# Altium schematic sheet sch1.SchDoc
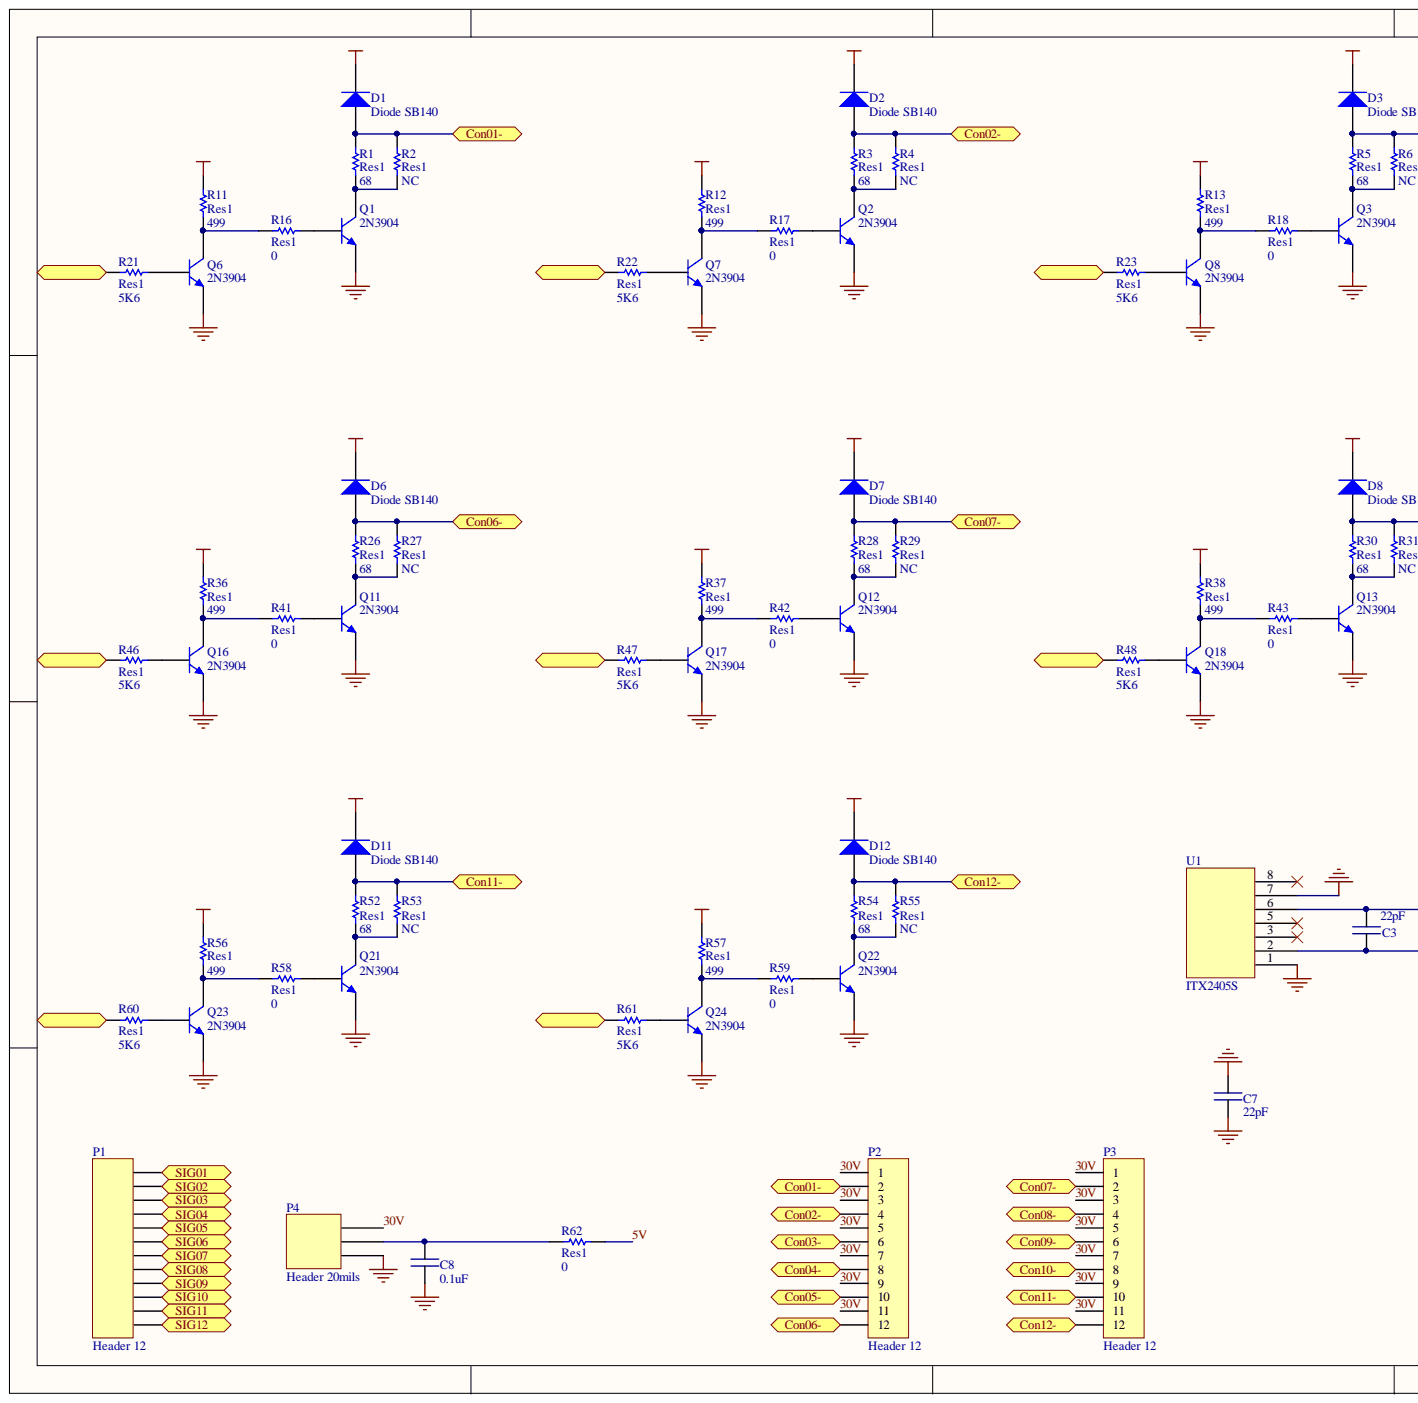
[diagram p0: sch1.SchDoc, left]
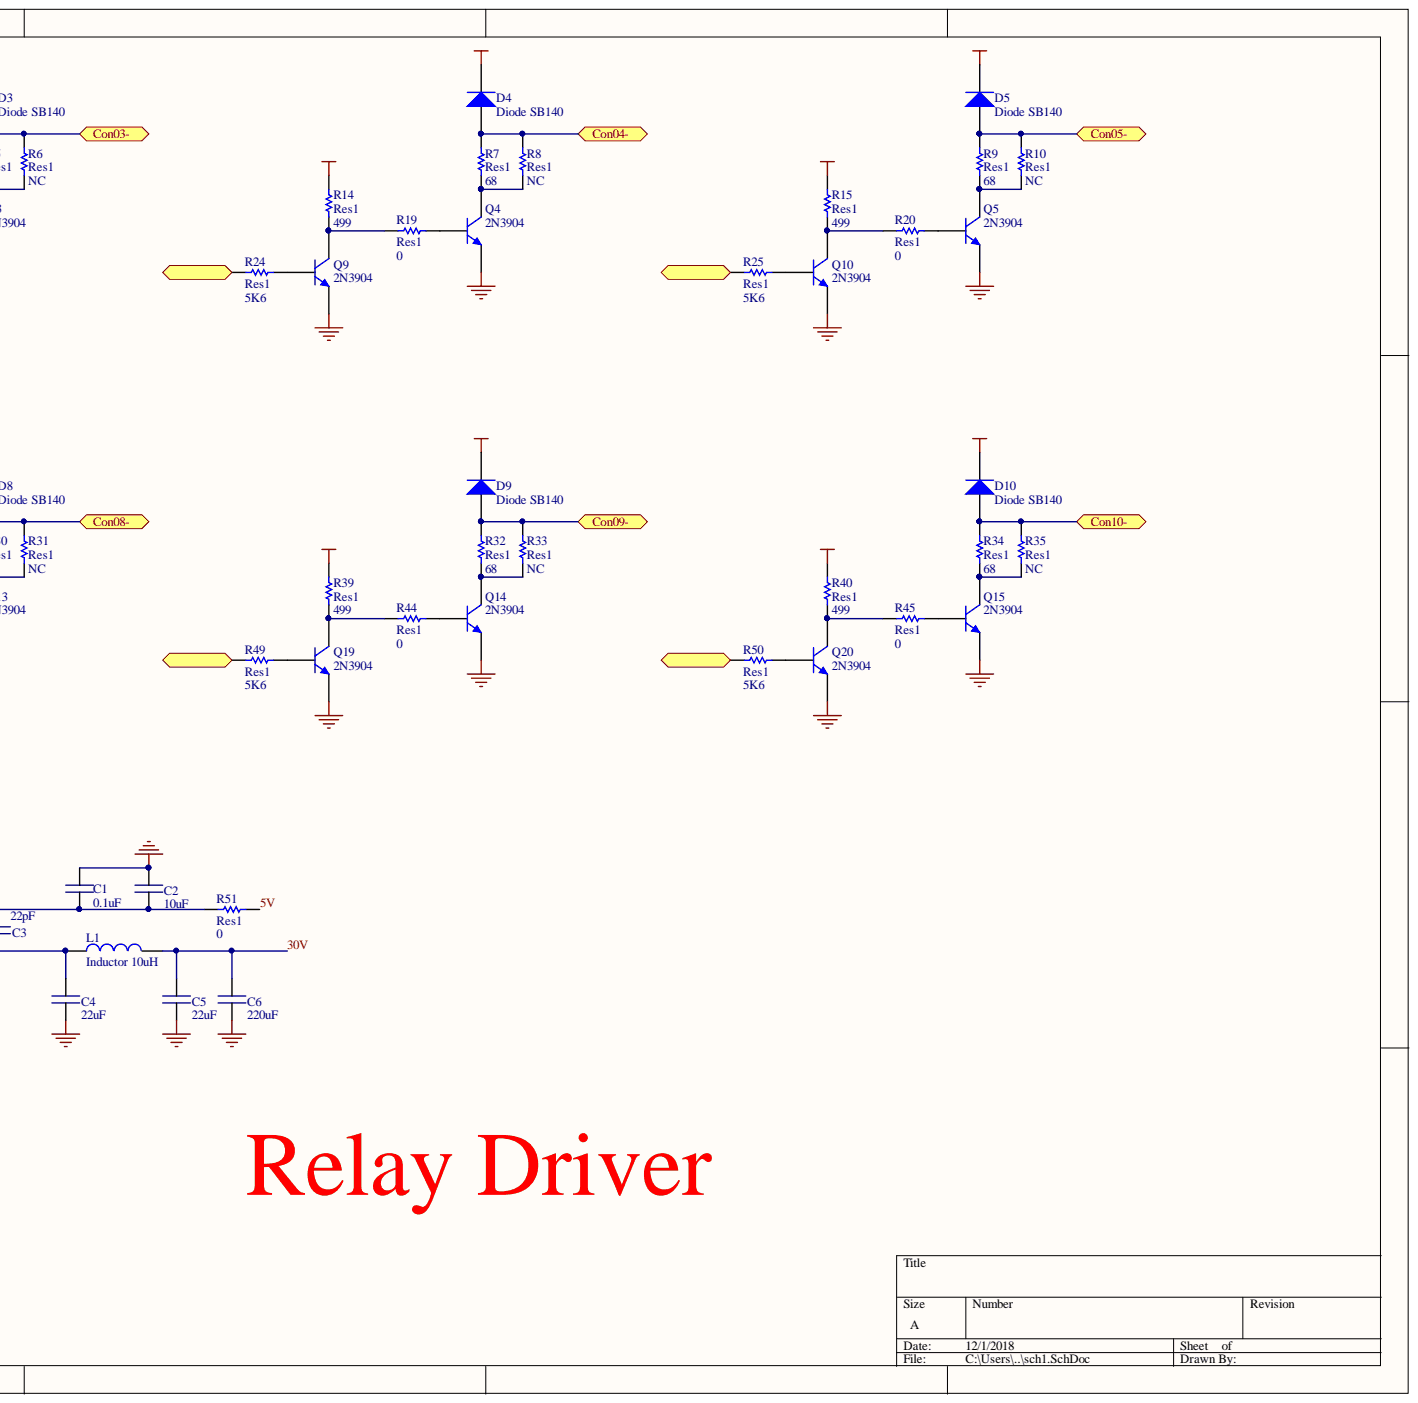
[diagram p1: sch1.SchDoc, right]

## Parts
C1  value 0.1uF  [0805 (2012 metric)]  (hidden comment: Cap 0603)
C2  value 10uF  [0805 (2012 metric)]  (hidden comment: Cap 0603)
C3  value 22pF  [0603 (1608 Metric)]  (hidden comment: Cap 0603)
C4  value 22uF  [0805 (2012 metric)]  (hidden comment: Cap 0603)
C5  value 22uF  [0805 (2012 metric)]  (hidden comment: Cap 0603)
C6  value 220uF  [0805 (2012 metric)]  (hidden comment: Cap 0603)
C7  value 22pF  [0603 (1608 Metric)]  (hidden comment: Cap 0603)
C8  value 0.1uF  [0603 (1608 Metric)]  (hidden comment: Cap 0603)
D1  Diode SB140  [DO-41]
D2  Diode SB140  [DO-41]
D3  Diode SB140  [DO-41]
D4  Diode SB140  [DO-41]
D5  Diode SB140  [DO-41]
D6  Diode SB140  [DO-41]
D7  Diode SB140  [DO-41]
D8  Diode SB140  [DO-41]
D9  Diode SB140  [DO-41]
D10  Diode SB140  [DO-41]
D11  Diode SB140  [DO-41]
D12  Diode SB140  [DO-41]
L1  Inductor 10uH  [Inductor Surface Mount]
P1  Header 12  [HDR1X12]
P2  Header 12  [HDR1X12]
P3  Header 12  [HDR1X12]
P4  Header 20mils  [Header_20mils]
Q1  2N3904  [TO-92A]
Q2  2N3904  [TO-92A]
Q3  2N3904  [TO-92A]
Q4  2N3904  [TO-92A]
Q5  2N3904  [TO-92A]
Q6  2N3904  [TO-92A]
Q7  2N3904  [TO-92A]
Q8  2N3904  [TO-92A]
Q9  2N3904  [TO-92A]
Q10  2N3904  [TO-92A]
Q11  2N3904  [TO-92A]
Q12  2N3904  [TO-92A]
Q13  2N3904  [TO-92A]
Q14  2N3904  [TO-92A]
Q15  2N3904  [TO-92A]
Q16  2N3904  [TO-92A]
Q17  2N3904  [TO-92A]
Q18  2N3904  [TO-92A]
Q19  2N3904  [TO-92A]
Q20  2N3904  [TO-92A]
Q21  2N3904  [TO-92A]
Q22  2N3904  [TO-92A]
Q23  2N3904  [TO-92A]
Q24  2N3904  [TO-92A]
R1  Res1  value 68  [AXIAL-0.6]
R2  Res1  value NC  [AXIAL-0.6]
R3  Res1  value 68  [AXIAL-0.6]
R4  Res1  value NC  [AXIAL-0.6]
R5  Res1  value 68  [AXIAL-0.6]
R6  Res1  value NC  [AXIAL-0.6]
R7  Res1  value 68  [AXIAL-0.6]
R8  Res1  value NC  [AXIAL-0.6]
R9  Res1  value 68  [AXIAL-0.6]
R10  Res1  value NC  [AXIAL-0.6]
R11  Res1  value 499  [6-0805_N]
R12  Res1  value 499  [6-0805_N]
R13  Res1  value 499  [6-0805_N]
R14  Res1  value 499  [6-0805_N]
R15  Res1  value 499  [6-0805_N]
R16  Res1  value 0  [6-0805_N]
R17  Res1  value 0  [6-0805_N]
R18  Res1  value 0  [6-0805_N]
R19  Res1  value 0  [6-0805_N]
R20  Res1  value 0  [6-0805_N]
R21  Res1  value 5K6  [6-0805_N]
R22  Res1  value 5K6  [6-0805_N]
R23  Res1  value 5K6  [6-0805_N]
R24  Res1  value 5K6  [6-0805_N]
R25  Res1  value 5K6  [6-0805_N]
R26  Res1  value 68  [AXIAL-0.6]
R27  Res1  value NC  [AXIAL-0.6]
R28  Res1  value 68  [AXIAL-0.6]
R29  Res1  value NC  [AXIAL-0.6]
R30  Res1  value 68  [AXIAL-0.6]
R31  Res1  value NC  [AXIAL-0.6]
R32  Res1  value 68  [AXIAL-0.6]
R33  Res1  value NC  [AXIAL-0.6]
R34  Res1  value 68  [AXIAL-0.6]
R35  Res1  value NC  [AXIAL-0.6]
R36  Res1  value 499  [6-0805_N]
R37  Res1  value 499  [6-0805_N]
R38  Res1  value 499  [6-0805_N]
R39  Res1  value 499  [6-0805_N]
R40  Res1  value 499  [6-0805_N]
R41  Res1  value 0  [6-0805_N]
R42  Res1  value 0  [6-0805_N]
R43  Res1  value 0  [6-0805_N]
R44  Res1  value 0  [6-0805_N]
R45  Res1  value 0  [6-0805_N]
R46  Res1  value 5K6  [6-0805_N]
R47  Res1  value 5K6  [6-0805_N]
R48  Res1  value 5K6  [6-0805_N]
R49  Res1  value 5K6  [6-0805_N]
R50  Res1  value 5K6  [6-0805_N]
R51  Res1  value 0  [6-0805_N]
R52  Res1  value 68  [AXIAL-0.6]
R53  Res1  value NC  [AXIAL-0.6]
R54  Res1  value 68  [AXIAL-0.6]
R55  Res1  value NC  [AXIAL-0.6]
R56  Res1  value 499  [6-0805_N]
R57  Res1  value 499  [6-0805_N]
R58  Res1  value 0  [6-0805_N]
R59  Res1  value 0  [6-0805_N]
R60  Res1  value 5K6  [6-0805_N]
R61  Res1  value 5K6  [6-0805_N]
R62  Res1  value 0  [6-0805_N]
U1  ITX2405S  [ITX2405S]

## Ports
Con01-, Con02-, Con03-, Con04-, Con05-, Con06-, Con07-, Con08-, Con09-, Con10-, Con11-, Con12-, SIG01, SIG02, SIG03, SIG04, SIG05, SIG06, SIG07, SIG08, SIG09, SIG10, SIG11, SIG12

## Nets
GND: C1.2 C2.2 C4.1 C5.1 C6.1 C7.1 C7.2 C8.1 P4.3 Q1.1 Q2.1 Q3.1 Q4.1 Q5.1 Q6.1 Q7.1 Q8.1 Q9.1 Q10.1 Q11.1 Q12.1 Q13.1 Q14.1 Q15.1 Q16.1 Q17.1 Q18.1 Q19.1 Q20.1 Q21.1 Q22.1 Q23.1 Q24.1 U1.1 U1.7
5V: R11.2 R12.2 R13.2 R14.2 R15.2 R36.2 R37.2 R38.2 R39.2 R40.2 R51.2 R56.2 R57.2 R62.2
30V: C5.2 C6.2 D1.2 D2.2 D3.2 D4.2 D5.2 D6.2 D7.2 D8.2 D9.2 D10.2 D11.2 D12.2 L1.2 P2.1 P2.3 P2.5 P2.7 P2.9 P2.11 P3.1 P3.3 P3.5 P3.7 P3.9 P3.11 P4.1
Con01-: D1.1 P2.2 R1.2 R2.2 ; port Con01-
Con02-: D2.1 P2.4 R3.2 R4.2 ; port Con02-
Con03-: D3.1 P2.6 R5.2 R6.2 ; port Con03-
Con04-: D4.1 P2.8 R7.2 R8.2 ; port Con04-
Con05-: D5.1 P2.10 R9.2 R10.2 ; port Con05-
Con06-: D6.1 P2.12 R26.2 R27.2 ; port Con06-
Con07-: D7.1 P3.2 R28.2 R29.2 ; port Con07-
Con08-: D8.1 P3.4 R30.2 R31.2 ; port Con08-
Con09-: D9.1 P3.6 R32.2 R33.2 ; port Con09-
Con10-: D10.1 P3.8 R34.2 R35.2 ; port Con10-
Con11-: D11.1 P3.10 R52.2 R53.2 ; port Con11-
Con12-: D12.1 P3.12 R54.2 R55.2 ; port Con12-
SIG01: P1.1 R21.2 ; port SIG01
SIG02: P1.2 R22.2 ; port SIG02
SIG03: P1.3 R23.2 ; port SIG03
SIG04: P1.4 R24.2 ; port SIG04
SIG05: P1.5 R25.2 ; port SIG05
SIG06: P1.6 R46.2 ; port SIG06
SIG07: P1.7 R47.2 ; port SIG07
SIG08: P1.8 R48.2 ; port SIG08
SIG09: P1.9 R49.2 ; port SIG09
SIG10: P1.10 R50.2 ; port SIG10
SIG11: P1.11 R60.2 ; port SIG11
SIG12: P1.12 R61.2 ; port SIG12
NetC1_1: C1.1 C2.1 C3.2 R51.1 U1.6
NetC3_1: C3.1 C4.2 L1.1 U1.2
NetC8_2: C8.2 P4.2 R62.1
NetQ1_2: Q1.2 R16.1
NetQ1_3: Q1.3 R1.1 R2.1
NetQ2_2: Q2.2 R17.1
NetQ2_3: Q2.3 R3.1 R4.1
NetQ3_2: Q3.2 R18.1
NetQ3_3: Q3.3 R5.1 R6.1
NetQ4_2: Q4.2 R19.1
NetQ4_3: Q4.3 R7.1 R8.1
NetQ5_2: Q5.2 R20.1
NetQ5_3: Q5.3 R9.1 R10.1
NetQ6_2: Q6.2 R21.1
NetQ6_3: Q6.3 R11.1 R16.2
NetQ7_2: Q7.2 R22.1
NetQ7_3: Q7.3 R12.1 R17.2
NetQ8_2: Q8.2 R23.1
NetQ8_3: Q8.3 R13.1 R18.2
NetQ9_2: Q9.2 R24.1
NetQ9_3: Q9.3 R14.1 R19.2
NetQ10_2: Q10.2 R25.1
NetQ10_3: Q10.3 R15.1 R20.2
NetQ11_2: Q11.2 R41.1
NetQ11_3: Q11.3 R26.1 R27.1
NetQ12_2: Q12.2 R42.1
NetQ12_3: Q12.3 R28.1 R29.1
NetQ13_2: Q13.2 R43.1
NetQ13_3: Q13.3 R30.1 R31.1
NetQ14_2: Q14.2 R44.1
NetQ14_3: Q14.3 R32.1 R33.1
NetQ15_2: Q15.2 R45.1
NetQ15_3: Q15.3 R34.1 R35.1
NetQ16_2: Q16.2 R46.1
NetQ16_3: Q16.3 R36.1 R41.2
NetQ17_2: Q17.2 R47.1
NetQ17_3: Q17.3 R37.1 R42.2
NetQ18_2: Q18.2 R48.1
NetQ18_3: Q18.3 R38.1 R43.2
NetQ19_2: Q19.2 R49.1
NetQ19_3: Q19.3 R39.1 R44.2
NetQ20_2: Q20.2 R50.1
NetQ20_3: Q20.3 R40.1 R45.2
NetQ21_2: Q21.2 R58.1
NetQ21_3: Q21.3 R52.1 R53.1
NetQ22_2: Q22.2 R59.1
NetQ22_3: Q22.3 R54.1 R55.1
NetQ23_2: Q23.2 R60.1
NetQ23_3: Q23.3 R56.1 R58.2
NetQ24_2: Q24.2 R61.1
NetQ24_3: Q24.3 R57.1 R59.2

Not connected: U1 3 5 8

## Library data of the parts
C1-C8 = Cap 0603: 0603 Cap; Component Kind: Standard; Library Name: Library_Microgrid.SchLib
D1-D12 = Diode 1N4006: 1 Amp General Purpose Rectifier; Code_JEDEC: DO-204-AL; Component Kind: Standard; ComponentLink1Description: Manufacturer Link; ComponentLink1URL: http://www.fairchildsemi.com/; ComponentLink2Description: Datasheet; ComponentLink2URL: http://www.fairchildsemi.com/ds/1N%2F1N4001.pdf; DatasheetVersion: 1998; LatestRevisionDate: 17-Jul-2002; LatestRevisionNote: Re-released for DXP Platform.; Library Name: Miscellaneous Devices.IntLib; PackageDescription: Diode, Axial; Body 5.2 x 2.7 mm (LxDia. max), Lead Dia. 0.86 mm (max); PackageReference: DO-41; PackageVersion: Aug-1999; Published: 8-Jun-2000; Signal Integrity: 1N4006; Simulation: 1N4006; Publisher: Altium Limited
L1 = Inductor 10uH: Surface Mount Inductor 744043100; Component Kind: Standard; Library Name: Library_Microgrid (Jorge Elizondo's conflicted copy 2015-04-14).SchLib
P1-P3 = Header 12: Header, 12-Pin; Component Kind: Standard; LatestRevisionDate: 17-Jul-2002; LatestRevisionNote: Re-released for DXP Platform.; Library Name: Miscellaneous Connectors.IntLib; Signal Integrity: Connector; Publisher: Altium Limited
Q1-Q24 = 2N3904: NPN General Purpose Amplifier; Component Kind: Standard; ComponentLink1Description: Manufacturer Link; ComponentLink1URL: http://www.fairchildsemi.com/; ComponentLink2Description: Datasheet; ComponentLink2URL: http://www.fairchildsemi.com/ds/2N%2F2N3904.pdf; DatasheetVersion: 1997; LatestRevisionDate: 15-Jan-2003; LatestRevisionNote: PCB Footprint 'BCY-W3/D4.7' replaced; Library Name: Miscellaneous Devices.IntLib; PackageDescription: TO, Flat Index; 3 In-Line, Axial Leads; Body Dia. 4.5mm; Leads 0.51 x 0.48 mm (max); PackageReference: TO-92A; PackageVersion: Sep-1998; Published: 8-Jun-2000; Signal Integrity: 2N3904; Simulation: 2N3904; Publisher: Altium Limited
R1-R62 = Res1: Resistor; Component Kind: Standard; LatestRevisionDate: 17-Jul-2002; LatestRevisionNote: Re-released for DXP Platform.; Library Name: Miscellaneous Devices.IntLib; PackageDescription: Axial Device, Thru-Hole; 2 Leads; 0.3 in Pin Spacing; PackageReference: AXIAL-0.3; Published: 8-Jun-2000; Signal Integrity: Res; Simulation: RESISTOR; Publisher: Altium Limited
P4 = Header 20mils: Component Kind: Standard; Description: Header 20mils; Library Name: connector_20mils.SchLib
U1 = ITX2405S: Component Kind: Standard; Description: ITX2405S; Library Name: Schlib1.SchLib
